# Altium schematic sheet СФ МЭИ ЛБ ПЭ2-18 11.03.04 08 Э3.SchDoc
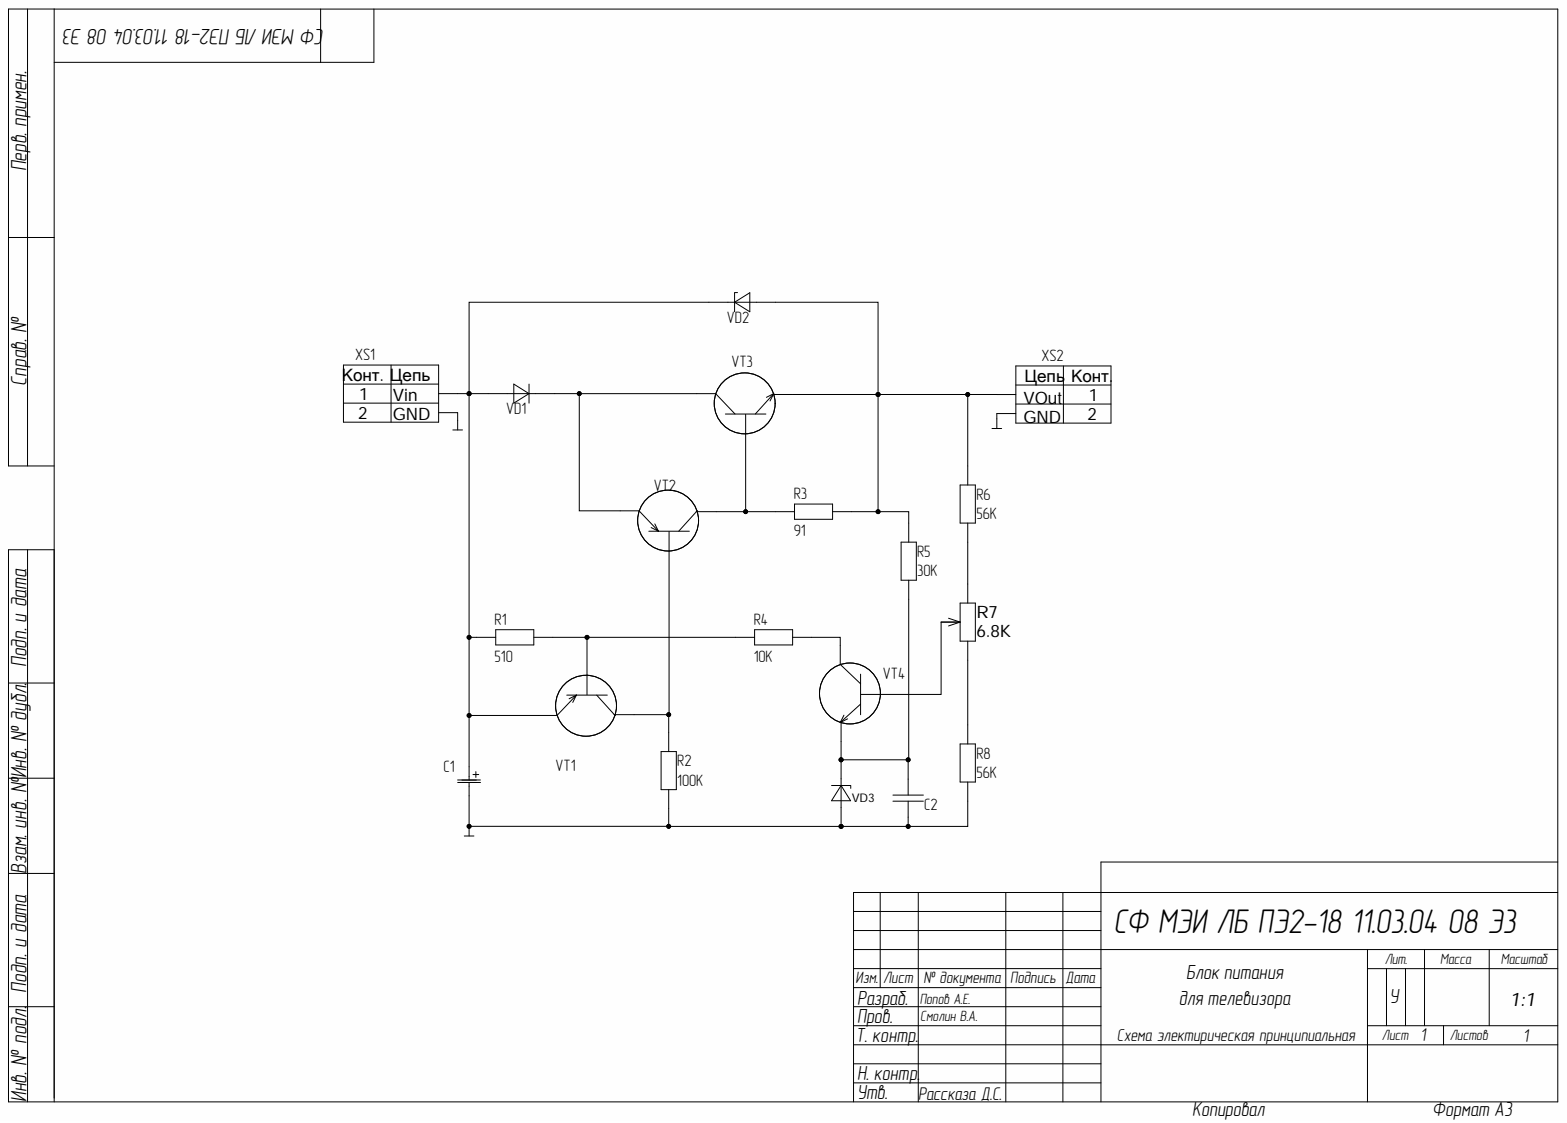
[diagram p0: СФ МЭИ ЛБ ПЭ2-18 11.03.04 08 Э3.SchDoc]

## Parts
C1  Part Number: 35TZV330M10X10.5  [CAP_J-V_10X10P5]  (value not drawn)
C2  0.068u  [0805]  (value not drawn)
R1  510  [0805]
R2  100K  [0805]
R3  91  [0805]
R4  10K  [0805]
R5  30K  [0805]
R6  56K  [0805]
R7  6.8K  [ST32]
R8  56K  [0805]
VD1  MMSZ5235B_1  [SOD-123]  (value not drawn)
VD2  MMSZ5235B  [SOD-123]  (value not drawn)
VD3  MMSZ5235B  [SOD-123]  (value not drawn)
VT1  Биполярный транзистор n-p-n  [SOT23]  (value not drawn)
VT2  Биполярный транзистор n-p-n  [SOT23]  (value not drawn)
VT3  Биполярный транзистор n-p-n  [SOT23]  (value not drawn)
VT4  Биполярный транзистор n-p-n  [SOT23]  (value not drawn)
XS1  DG126-5.0-0.2P  [DG126-5.0-02P]  (value not drawn)
XS2  DG126-5.0-0.2P  [DG126-5.0-02P]  (value not drawn)

## Nets
NetC1_1: C1.1 R1.1 VD1.1 VD2.2 VT1.2 XS1.1
NetC1_2: C1.2 C2.1 R2.1 R8.1 VD3.1 XS1.2 XS2.2
NetC2_2: C2.2 R5.1 VD3.2 VT4.3
NetR1_2: R1.2 R4.1 VT1.1
NetR2_2: R2.2 VT1.3 VT2.1
NetR3_1: R3.1 VT2.3 VT3.1
NetR3_2: R3.2 R5.2 R6.2 VD2.1 VT3.3 XS2.1
NetR4_2: R4.2 VT4.2
NetR6_1: R6.1 R7.2
NetR7_1: R7.1 R8.2
NetR7_3: R7.3 VT4.1
NetVD1_2: VD1.2 VT2.2 VT3.2

## Library data of the parts
C1 = 35TZV330M10X10.5: No Description Available; Built_By: EMA_Cory; Type: DEV; Vendor: Rubycon Netherlands; Component Kind: Standard; Manufacturer_Part_Number: 35TZV330M10X10.5; RefDes: RefDes; Value: Value; Copyright: Copyright (C) 2018 Accelerated Designs. All rights reserved; Library Name: SMD Elektrilits.IntLib
C2 = К53-68"R"-25В-0.068 мкФ ±10% 0805: К53-68"R"-25В-0.068 мкФ ±10%; Component Kind: Standard; Library Name: УГО.SchLib
R1-R6 R8 = 0.125Вт 0805 300 Ом, 5%, Чип резистор (SMD): 0805-0.125 Вт -300 Ом±5%; Component Kind: Standard; Library Name: УГО.SchLib
R7 = 0.125Вт 0805 6.8 кОм, 5%, подстроечный чип резистор (SMD): 0805-0.125 Вт -6.8 кОм±5%; Component Kind: Standard; Library Name: УГО.SchLib
VD1 = MMSZ5235B_1: MMSZ5235B; Component Kind: Standard; Library Name: УГО.SchLib
VT1 VT2 = IRFML8244TRPBF_1: IRFML8244TRPBF; Component Kind: Standard; Library Name: УГО.SchLib
VT3 VT4 = IRFML8244TRPBF: Component Kind: Standard; Description: IRFML8244TRPBF; Library Name: УГО.SchLib
VD2 VD3 = MMSZ5235B: Component Kind: Standard; Description: MMSZ5235B; Library Name: УГО.SchLib
XS1 XS2 = DG126-5.0-0.2P: Component Kind: Standard; Description: DG126-5.0-0.2P; Library Name: УГО.SchLib
